# Altium schematic sheet wifi_.SchDoc(wifi_)
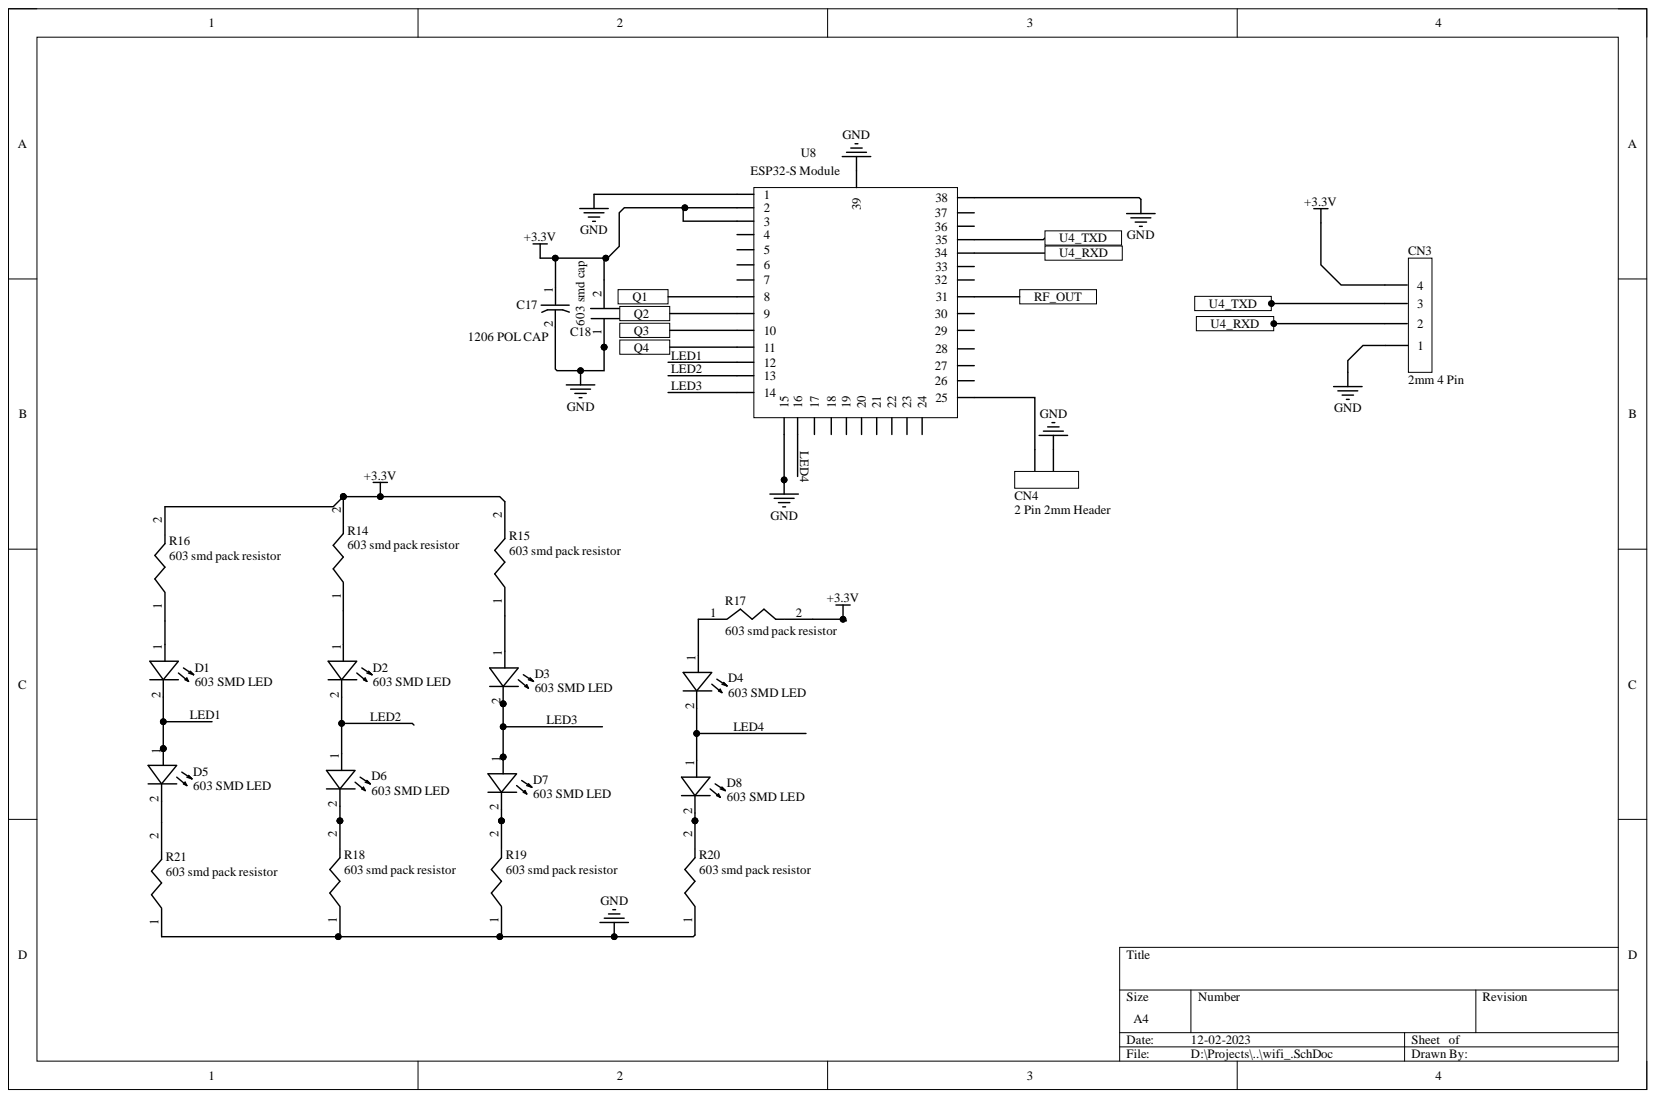
[diagram p0: wifi_.SchDoc]

## Parts
C17  1206 POL CAP  [1206 POL CAP]
C18  603 smd cap  [603]
CN3  2mm 4 Pin  [4_Pin 2mm Header]
CN4  2 Pin 2mm Header  [2mm 2 pin header]
D1  603 SMD LED  [603 SMD LED]
D2  603 SMD LED  [603 SMD LED]
D3  603 SMD LED  [603 SMD LED]
D4  603 SMD LED  [603 SMD LED]
D5  603 SMD LED  [603 SMD LED]
D6  603 SMD LED  [603 SMD LED]
D7  603 SMD LED  [603 SMD LED]
D8  603 SMD LED  [603 SMD LED]
R14  603 smd pack resistor  [603]
R15  603 smd pack resistor  [603]
R16  603 smd pack resistor  [603]
R17  603 smd pack resistor  [603]
R18  603 smd pack resistor  [603]
R19  603 smd pack resistor  [603]
R20  603 smd pack resistor  [603]
R21  603 smd pack resistor  [603]
U8  ESP32-S Module  [ESP32_S]

## Ports
Q1, Q2, Q3, Q4, RF_OUT, U4_RXD, U4_TXD

## Nets
+3.3V: C17.1 C18.2 CN3.4 R14.2 R15.2 R16.2 R17.2 U8.2 U8.3
GND: C17.2 C18.1 CN3.1 CN4.2 R18.1 R19.1 R20.1 R21.1 U8.1 U8.15 U8.38 U8.39
LED1: D1.2 D5.1 U8.12
LED2: D2.2 D6.1 U8.13
LED3: D3.2 D7.1 U8.14
LED4: D4.2 D8.1 U8.16
Q1: U8.8 ; port Q1
Q2: U8.9 ; port Q2
Q3: U8.10 ; port Q3
Q4: U8.11 ; port Q4
RF_OUT: U8.31 ; port RF_OUT
U4_RXD: CN3.2 U8.34 ; port U4_RXD
U4_TXD: CN3.3 U8.35 ; port U4_TXD
NetCN4_1: CN4.1 U8.25
NetD1_1: D1.1 R16.1
NetD2_1: D2.1 R14.1
NetD3_1: D3.1 R15.1
NetD4_1: D4.1 R17.1
NetD5_2: D5.2 R21.2
NetD6_2: D6.2 R18.2
NetD7_2: D7.2 R19.2
NetD8_2: D8.2 R20.2

Not connected: U8 4 5 6 7 17 18 19 20 21 22 23 24 26 27 28 29 30 32 33 36 37

## Library data of the parts
CN3 = 4_PIN header 2mm: Component Kind: Standard; Library Name: Custom1.IntLib
CN4 = 2_PIN 2mm Header: Component Kind: Standard; Library Name: Custom1.IntLib
D1-D8 = 603 LED: Component Kind: Standard; Library Name: Custom1.IntLib
R14-R21 = 603 Resistor: Component Kind: Standard; Library Name: Custom1.IntLib
C17 = 1206 POL CAP: Component Kind: Standard; Library Name: Custom1.IntLib
C18 = 603 SMD CAP: Component Kind: Standard; Library Name: Custom1.IntLib
U8 = ESP32-S Module: Component Kind: Standard; Library Name: Custom1.IntLib
